# Altium schematic sheet Mechanical Interfacing.SchDoc
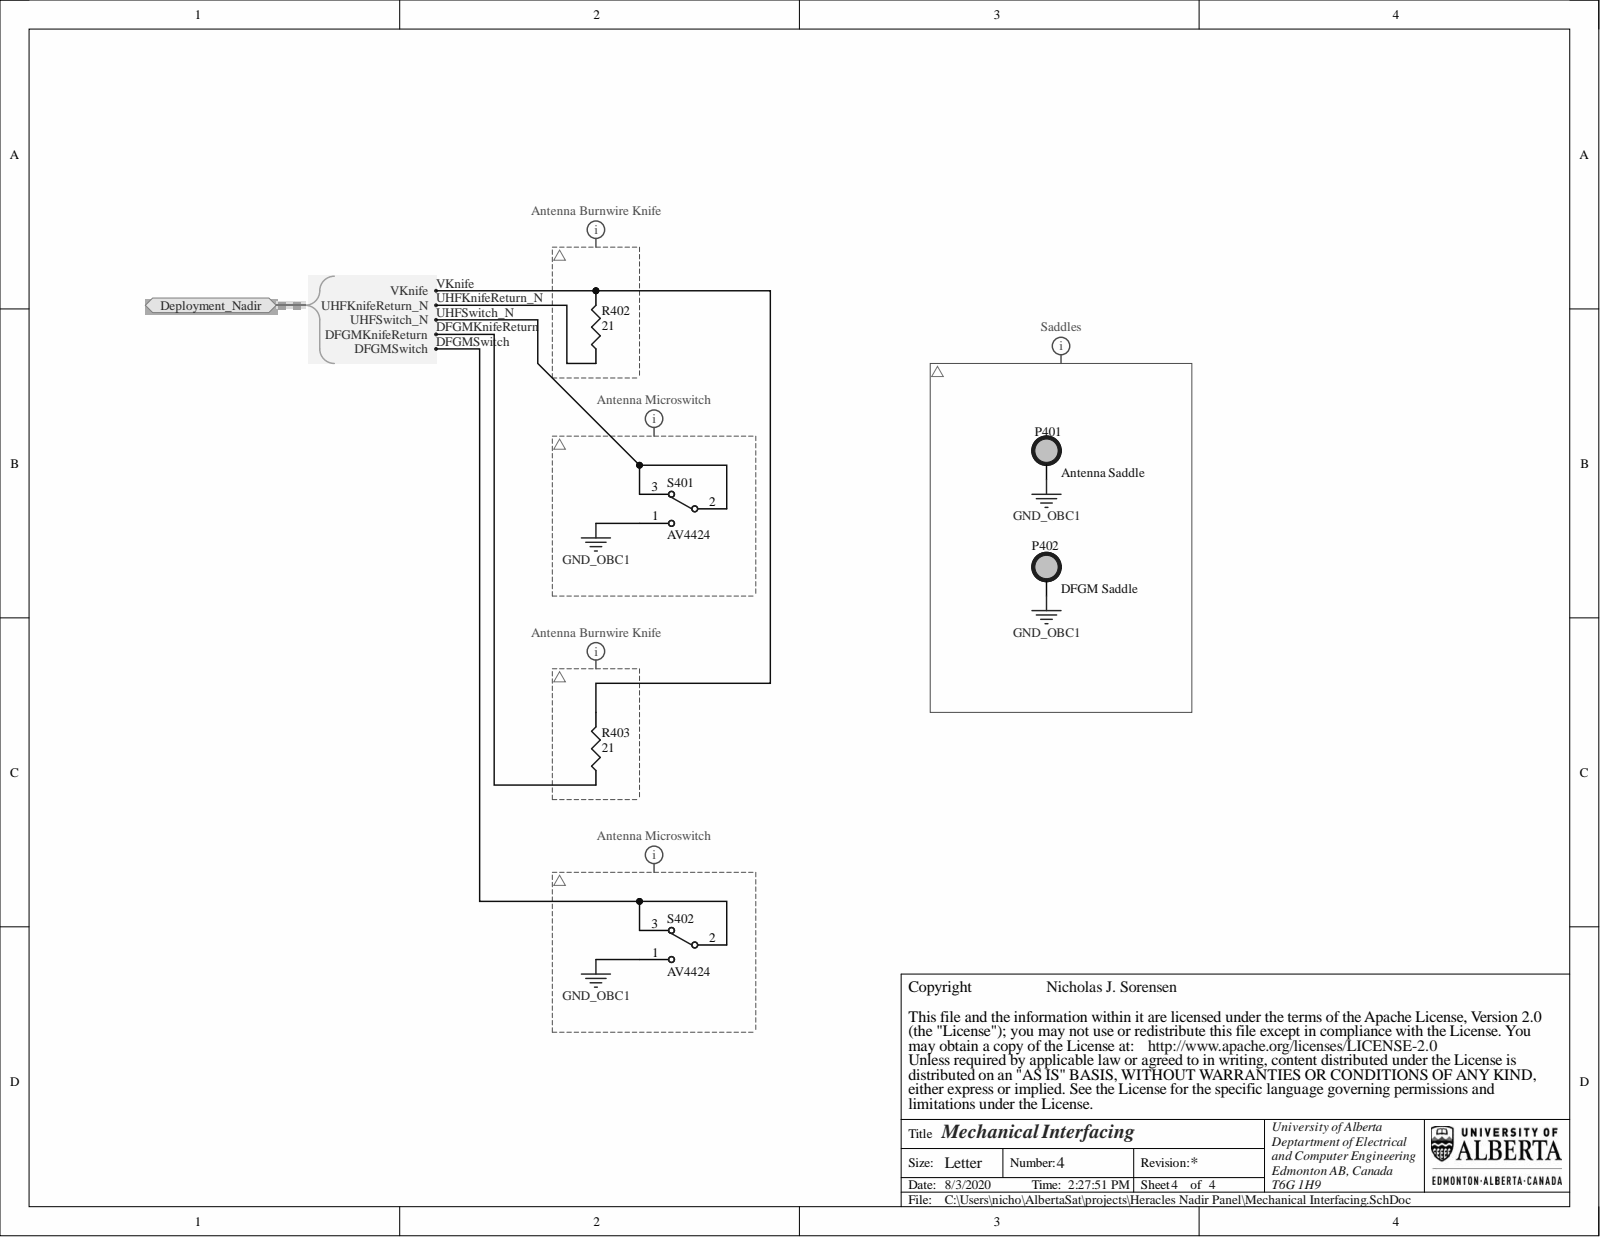
[diagram p0: Mechanical Interfacing.SchDoc]

## Parts
P401  Antenna Saddle  [ANTENNA_SADDLE]
P402  DFGM Saddle  [DFGM_Saddle]
R402  value 21  [VSH-CPF1]  (hidden comment: Res3)
R403  value 21  [VSH-CPF1]  (hidden comment: Res3)
S401  AV4424  [PANA-AV4424]
S402  AV4424  [PANA-AV4424]

## Ports
Deployment_Nadir, Deployment_Nadir.DFGMKnifeReturn, Deployment_Nadir.DFGMSwitch, Deployment_Nadir.UHFKnifeReturn_N, Deployment_Nadir.UHFSwitch_N, Deployment_Nadir.VKnife

## Nets
GND_OBC1: P401.1 P402.1 S401.1 S402.1
DFGMKnifeReturn: R403.1 ; port Deployment_Nadir
DFGMSwitch: S402.2 S402.3 ; port Deployment_Nadir
UHFKnifeReturn_N: R402.1 ; port Deployment_Nadir
UHFSwitch_N: S401.2 S401.3 ; port Deployment_Nadir
VKnife: R402.2 R403.2 ; port Deployment_Nadir

## Library data of the parts
P401 = PXX-ABS-00001: Manufacturer Part Number: Custom; Database Table Name: AlbertaSat_Cust; Index: 1; Component Kind: Standard; Designator: M?; LatestRevisionNote: ...; Library Name: Database.DbLib; Part Number: PXX-ABS-00001; Supplier 1: Digi-key; Publisher: AlbertaSat
P402 = PXX-ABS-00010: Manufacturer Part Number: Custom; Database Table Name: AlbertaSat_Cust; Index: 10; Component Kind: Standard; Designator: P?; LatestRevisionNote: ...; Library Name: Database.DbLib; Part Number: PXX-ABS-00010; Supplier 1: Digi-key; Publisher: AlbertaSat
R402 R403 = PR-VSH-00317: 21 Ohms ±1% 1W Through Hole Resistor Axial Flame Proof, Moisture Resistant, Safety Metal Film; Manufacturer Part Number: CPF121R000FKEE6; Database Table Name: Vishay; Index: 317; Temperature Coefficient: ±100ppm/°C; Component Kind: Standard; Designator: R?; LatestRevisionDate: 2/27/2020; LatestRevisionNote: ...; Library Name: Database.DbLib; PackageDescription: Chip Resistor; PackageReference: VSH-CPF1; Part Number: PR-VSH-00317; Power - Rated: 1W; Published: 2/27/2020; Signal Integrity: Res; Simulation: RESISTOR; Supplier 1: Digi-key; Supplier Link: #https://www.digikey.ca/product-detail/en/vishay-dale/CPF121R000FKEE6/CPF21.0CCT-ND/2713126#; Supplier Part Number 1: CPF21.0CCT-ND; Tolerance: ±1%; Publisher: AlbertaSat
S401 S402 = 20035: SWITCH SNAP ACT SPDT 500MA 30V; Database Table Name: Panasonic; Component Kind: Standard; Library Name: Database.DbLib; Manufacturer Part Number: AV4424; Part Number: 20035; Supplier 1: Digi-Key; Supplier Link: #https://www.digikey.com/product-detail/en/panasonic-electric-works/AV4424/255-3743-ND/646766#; Supplier Part Number 1: 255-3743-ND
